# Altium schematic sheet Act & Sen.SchDoc("Act & Sen")
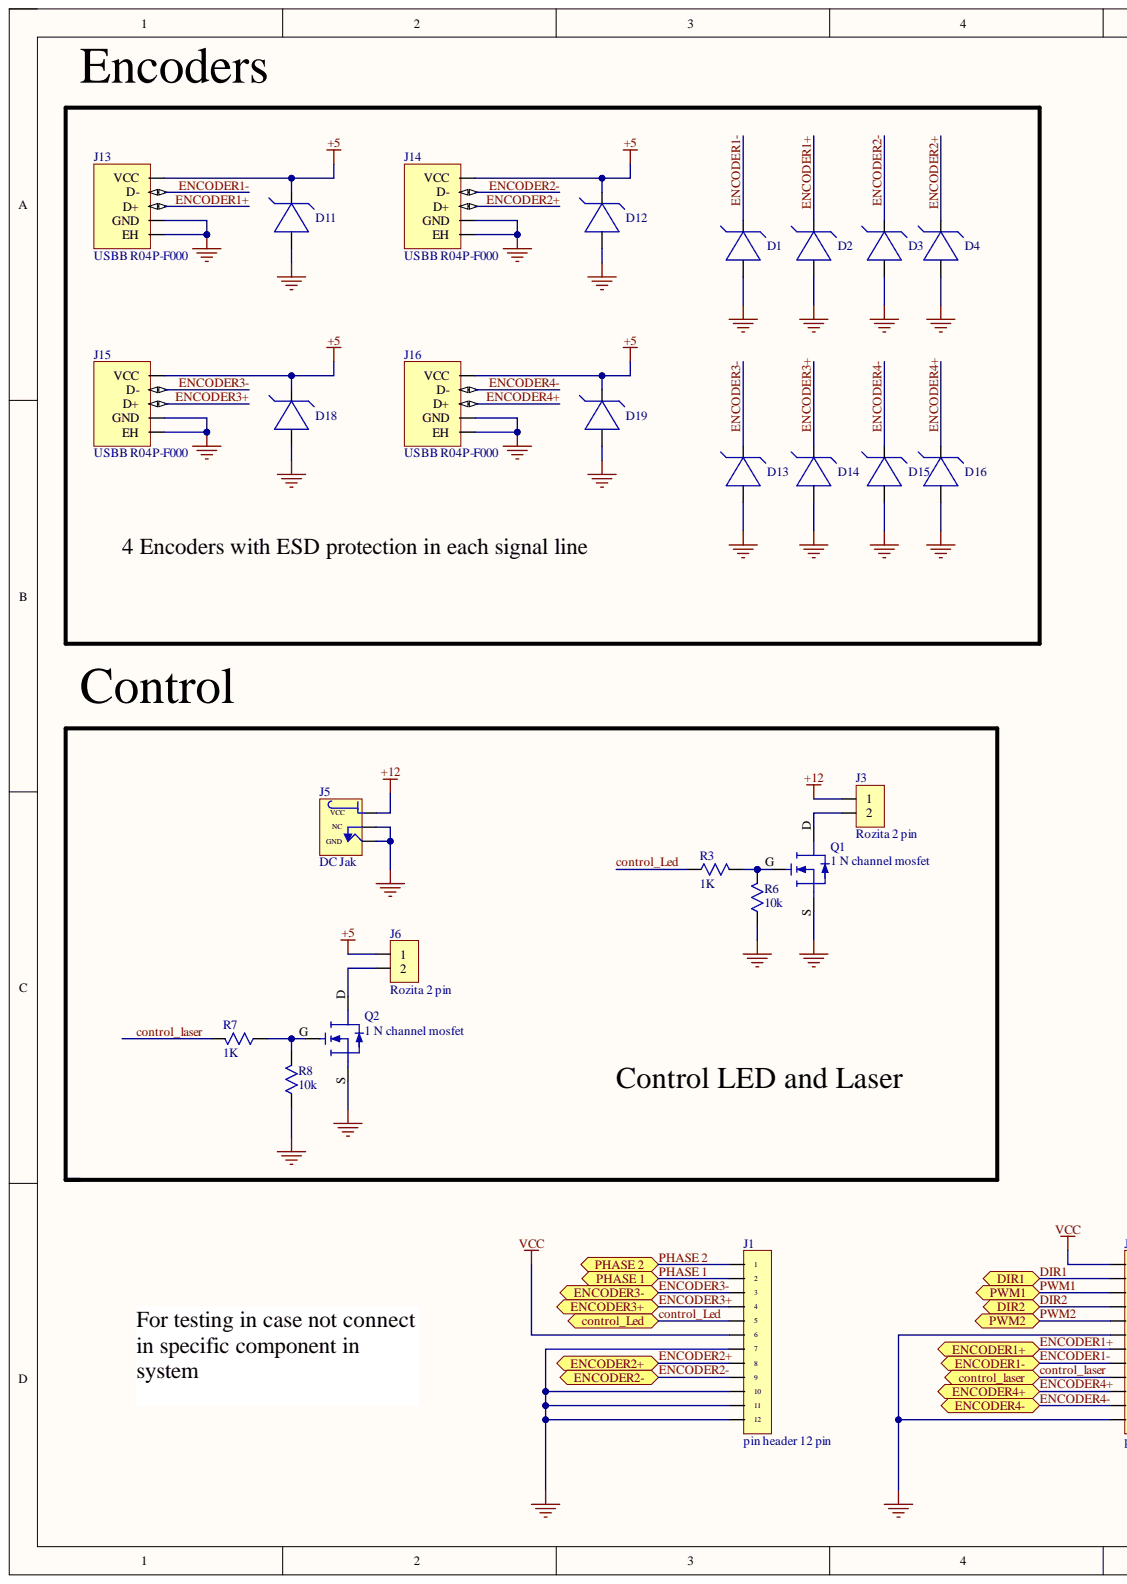
[diagram p0: Act & Sen.SchDoc, left]
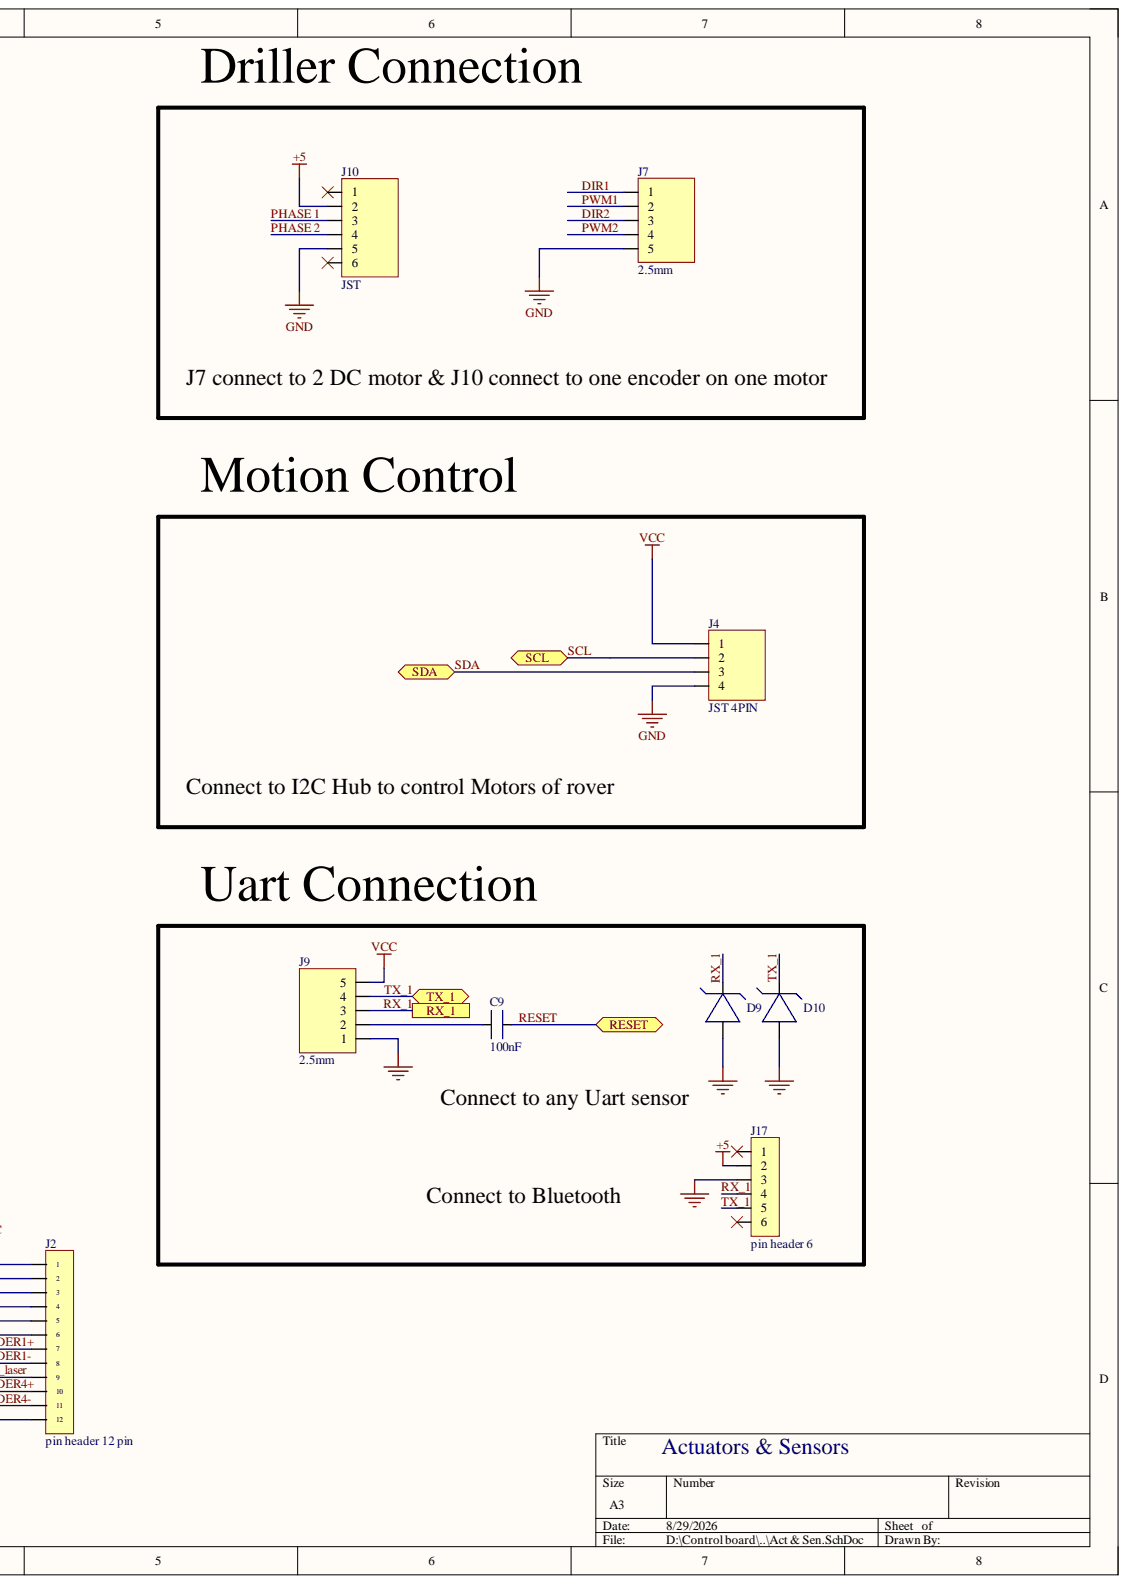
[diagram p1: Act & Sen.SchDoc, right]

## Parts
C9  100nF  [0603 CAP]
D1  ESD protection diode  [ESD protection diode]  (value not drawn)
D2  ESD protection diode  [ESD protection diode]  (value not drawn)
D3  ESD protection diode  [ESD protection diode]  (value not drawn)
D4  ESD protection diode  [ESD protection diode]  (value not drawn)
D9  ESD protection diode  [ESD protection diode]  (value not drawn)
D10  ESD protection diode  [ESD protection diode]  (value not drawn)
D11  ESD protection diode  [ESD protection diode]  (value not drawn)
D12  ESD protection diode  [ESD protection diode]  (value not drawn)
D13  ESD protection diode  [ESD protection diode]  (value not drawn)
D14  ESD protection diode  [ESD protection diode]  (value not drawn)
D15  ESD protection diode  [ESD protection diode]  (value not drawn)
D16  ESD protection diode  [ESD protection diode]  (value not drawn)
D18  ESD protection diode  [ESD protection diode]  (value not drawn)
D19  ESD protection diode  [ESD protection diode]  (value not drawn)
J1  pin header 12 pin  [pin headers 12 pin]
J2  pin header 12 pin  [pin headers 12 pin]
J3  Rozita 2 pin  [Rozita]
J4  JST 4PIN  [JST 4PIN]
J5  DC Jak  [DC Jack]
J6  Rozita 2 pin  [Rozita]
J7  2.5mm  [JST 5 PIN 2.5 MM]
J9  2.5mm  [JST 5 PIN 2.5 MM]
J10  JST  [JST 6PIN]
J13  USBB R04P-F000  [USBB]
J14  USBB R04P-F000  [USBB]
J15  USBB R04P-F000  [USBB]
J16  USBB R04P-F000  [USBB]
J17  pin header 6  [pin header 6 pin]
Q1  1 N channel mosfet  [1 N channel mosfet]
Q2  1 N channel mosfet  [1 N channel mosfet]
R3  1K  [0603 RES]
R6  10k  [0603 RES]
R7  1K  [0603 RES]
R8  10k  [0603 RES]

## Ports
control_laser, control_Led, DIR1, DIR2, ENCODER1+, ENCODER1-, ENCODER2+, ENCODER2-, ENCODER3+, ENCODER3-, ENCODER4+, ENCODER4-, PHASE 1, PHASE 2, PWM1, PWM2, RESET, RX_1, SCL, SDA, TX_1

## Nets
+5: D11.2 D12.2 D18.2 D19.2 J6.1 J10.2 J13.1(VCC) J14.1(VCC) J15.1(VCC) J16.1(VCC) J17.2
+12: J3.1 J5.1
GND: D1.1 D2.1 D3.1 D4.1 D9.1 D10.1 D11.1 D12.1 D13.1 D14.1 D15.1 D16.1 D18.1 D19.1 J1.7 J1.10 J1.11 J1.12 J2.6 J2.12 J4.4 J5.2 J5.3 J7.5 J9.1 J10.5 J13.4(GND) J13.5(EH) J14.4(GND) J14.5(EH) J15.4(GND) J15.5(EH) J16.4(GND) J16.5(EH) J17.3 Q1.S Q2.S R6.1 R8.1
VCC: J1.6 J2.1 J4.1 J9.5
control_laser: J2.9 R7.2 ; port control_laser
control_Led: J1.5 R3.1 ; port control_Led
DIR1: J2.2 J7.1 ; port DIR1
DIR2: J2.4 J7.3 ; port DIR2
ENCODER1+: D2.2 J2.7 J13.3(D+) ; port ENCODER1+
ENCODER1-: D1.2 J2.8 J13.2(D-) ; port ENCODER1-
ENCODER2+: D4.2 J1.8 J14.3(D+) ; port ENCODER2+
ENCODER2-: D3.2 J1.9 J14.2(D-) ; port ENCODER2-
ENCODER3+: D14.2 J1.4 J15.3(D+) ; port ENCODER3+
ENCODER3-: D13.2 J1.3 J15.2(D-) ; port ENCODER3-
ENCODER4+: D16.2 J2.10 J16.3(D+) ; port ENCODER4+
ENCODER4-: D15.2 J2.11 J16.2(D-) ; port ENCODER4-
PHASE 1: J1.2 J10.3 ; port PHASE 1
PHASE 2: J1.1 J10.4 ; port PHASE 2
PWM1: J2.3 J7.2 ; port PWM1
PWM2: J2.5 J7.4 ; port PWM2
RESET: C9.1 ; port RESET
RX_1: D9.2 J9.3 J17.4 ; port RX_1
SCL: J4.2 ; port SCL
SDA: J4.3 ; port SDA
TX_1: D10.2 J9.4 J17.5 ; port TX_1
NetC9_2: C9.2 J9.2
NetJ3_2: J3.2 Q1.D
NetJ6_2: J6.2 Q2.D
NetQ1_G: Q1.G R3.2 R6.2
NetQ2_G: Q2.G R7.1 R8.2

Not connected: J10 1 6; J17 1 6

## Library data of the parts
C9 = 100nF: 50V 100nF X7R ±10% 0603 Multilayer Ceramic Capacitors MLCC - SMD/SMT ROHS; Manufacturer 1: YAGEO; Manufacturer Part Number 1: CC0603KRX7R9BB104; Component Kind: Standard; Library Name: Schlib1.SchLib; Link: https://www.lcsc.com/product-detail/Multilayer-Ceramic-Capacitors-MLCC-SMD-SMT_YAGEO-CC0603KRX7R9BB104_C14663.html; Supplier 1: LCSC; Supplier Part Number 1: C14663
D1-D4 D9-D16 D18 D19 = ESD protection diode: SOT-5X3 ESD and Surge Protection (TVS/ESD) ROHS; Manufacturer 1: Texas Instruments; Manufacturer Part Number 1: ESD321DYAR; Component Kind: Standard; Library Name: Schlib1.SchLib; Link: https://www.lcsc.com/product-detail/ESD-and-Surge-Protection-TVS-ESD_Texas-Instruments-ESD321DYAR_C20345394.html; Supplier 1: LCSC; Supplier Part Number 1: C20345394
J1 J2 = pin header 12 pin: 12P 1x12P Gold Brass -40℃~+105℃ Pin Header Black 2.54mm 2.5mm 6mm 3mm 3A 1 Through Hole Through Hole,P=2.54mm Headers, Male Pins RoHS; Manufacture part number 1: HC-PZ254-11.5L-1x12PZ; Manufacturer 1: Hong Cheng; Component Kind: Standard; Library Name: Schlib1.SchLib; Link: https://www.lcsc.com/product-detail/C27985195.html?s_z=n_12%2520pin%2520header%25201x12; Supplier 1: LCSC; Supplier Part Number 1: C27985195
J3 J6 = Rozita 2 pin: 1x2P 18A -40℃~+105℃ 250V Green 12~30 Direct Insert 5.08mm 2.5 1 2 Plugin,P=5.08mm Screw Terminal Blocks ROHS; Manufacturer 1: DORABO; Manufacturer Part Number 1: DB128L-5.08-2P-GN-S; Component Kind: Standard; Library Name: Schlib1.SchLib; Link: https://www.lcsc.com/product-detail/Screw-Terminal-Blocks_DORABO-DB128L-5-08-2P-GN-S_C395868.html; Supplier 1: LCSC; Supplier Part Number 1: C395868
J4 = JST 4PIN: 1x4P 4P XH Tin 4 -25℃~+85℃ 3A 1 2.5mm Copper Direct Insert Plugin,P=2.5mm Wire To Board Connector ROHS; Manufacturer 1: Hong Cheng; Manufacturer Part Number 1: HC-XH-4A-TW; Component Kind: Standard; Library Name: Schlib1.SchLib; Link: https://www.lcsc.com/product-detail/Wire-To-Board-Connector_Hong-Cheng-HC-XH-4A-TW_C19273766.html?s_z=n_C19273766; Supplier 1: LCSC; Supplier Part Number 1: C19273766
J5 = DC Jak: Bend-in DC Power Receptacle -20℃~+70℃ 2.5mm 6.5mm 5A 24V Plugin DC Power Connectors ROHS; Manufacturer 1: XKB Connection; Manufacturer Part Number 1: DC-005-5A-2.5; Component Kind: Standard; Library Name: Schlib1.SchLib; Link: https://www.lcsc.com/product-detail/DC-Power-Connectors_XKB-Connection-DC-005-5A-2-5_C381115.html?s_z=n_DC%2520Power%2520Receptacle%25202.5mm%25206.4mm%25205A%2520%2520Plugin%2520DC%2520Power%2520Connectors%2520ROHS; Supplier 1: LCSC; Supplier Part Number 1: C381115
J7 J9 = jst 5pin 2.5mm: 1x5P 5P XH Tin 5 -25℃~+85℃ 3A 1 2.5mm Brass Direct Insert Plugin,P=2.5mm Wire To Board Connector ROHS; Manufacturer 1: JST; Manufacturer Part Number 1: B5B-XH-A(LF)(SN); Component Kind: Standard; Library Name: Schlib1.SchLib; Link: https://www.lcsc.com/product-detail/Wire-To-Board-Connector_JST-B5B-XH-A-LF-SN_C157991.html; Supplier 1: LCSC; Supplier Part Number 1: C157991
J10 = JST 6 PIN: 1x6P 6P Tin -25℃~+85℃ White 2.54mm 250V 6 2A 1 Through Hole Through Hole,P=2.54mm Headers, Male Pins RoHS; Manufacturer 1: JXTCONN; Manufacturer Part Number 1: A254F2L1-2W6P11; Component Kind: Standard; Library Name: Schlib1.SchLib; Link: https://www.lcsc.com/product-detail/Wire-To-Board-Connector_Hong-Cheng-HC-XH-4A-TW_C19273766.html?s_z=n_C19273766; Supplier 1: LCSC; Supplier Part Number 1: C41409653
J13-J16 = USBB R04P-F000: USB 2.0 1 4P Female Type-B Plugin USB Connectors ROHS; Manufacturer 1: Ckmtw(Shenzhen Cankemeng); Manufacturer Part Number 1: U-USBBR04P-F000; Component Kind: Standard; Library Name: Schlib1.SchLib; Link: https://www.lcsc.com/product-detail/USB-Connectors_Ckmtw-Shenzhen-Cankemeng-U-USBBR04P-F000_C189733.html?s_z=n_usbb; Supplier 1: lcsc; Supplier Part Number 1: C189733
J17 = pin header 6: Straight Policy 2.5mm 6P 6mm -40℃~+105℃ 3mm 2.54mm Single Row Black Brass 1x6P Plugin,P=2.54mm Pin Headers ROHS; Manufacture part number 1: PZ254V-11-06P; Manufacturer 1: XFCN; Component Kind: Standard; Library Name: Schlib1.SchLib; Link: https://www.lcsc.com/product-detail/Pin-Headers_XFCN-PZ254V-11-06P_C492405.html?s_z=n_Connectors%257CPin%2520Headers; Supplier 1: LCSC; Supplier part number 1: C492405
Q1 Q2 = 1 N channel mosfet: 20V 7A 1.25W 10mΩ@4.5V,7A 500mV 1 N-channel SOT-23-3L MOSFETs ROHS; Manufacturer 1: Siliup; Manufacturer part number 1: SP20N09T1; Component Kind: Standard; Library Name: Schlib1.SchLib; Link: https://www.lcsc.com/product-detail/MOSFETs_Siliup-SP20N09T1_C41354900.html; Supplier 1: LCSC; Supplier part number 1: C41354900
R3 R7 = RES 1K ohm: 100mW Thick Film Resistors 75V ±100ppm/℃ ±5% 1kΩ 0603 Chip Resistor - Surface Mount ROHS; Manufacturer 1: FOJAN; Manufacturer Part Number 1: FRC0603J102 TS; Component Kind: Standard; Library Name: Schlib1.SchLib; Link: https://www.lcsc.com/product-detail/Chip-Resistor-Surface-Mount_FOJAN-FRC0603J102-TS_C2907113.html; Supplier 1: LCSC; Supplier Part Number 1: C2907113
R6 R8 = RES 10kohm: 100mW Thin Film Resistor 75V ±25ppm/℃ ±0.1% 10kΩ 0603 Chip Resistor - Surface Mount ROHS; Manufacturer 1: YAGEO; Manufacturer Part Number 1: RT0603BRD0710KL; Component Kind: Standard; Library Name: Schlib1.SchLib; Link: https://www.lcsc.com/product-detail/Chip-Resistor-Surface-Mount_YAGEO-RT0603BRD0710KL_C95204.html?s_z=n_100mW%2520Thin%2520Film%2520Resistor%252075V%2520%25C2%25B125ppm%252F%25E2%2584%2583%2520%25C2%25B10.1%2525%252010k%25CE%25A9%25200603%2520Chip%2520Resistor%2520-%2520Surface%2520Mount%2520ROHS; Supplier 1: LCSC; Supplier Part Number 1: C95204
